# Altium schematic sheet AUDINT003_RevA_DUTHeader.SchDoc(AUDINT003_RevA_DUTHeader)
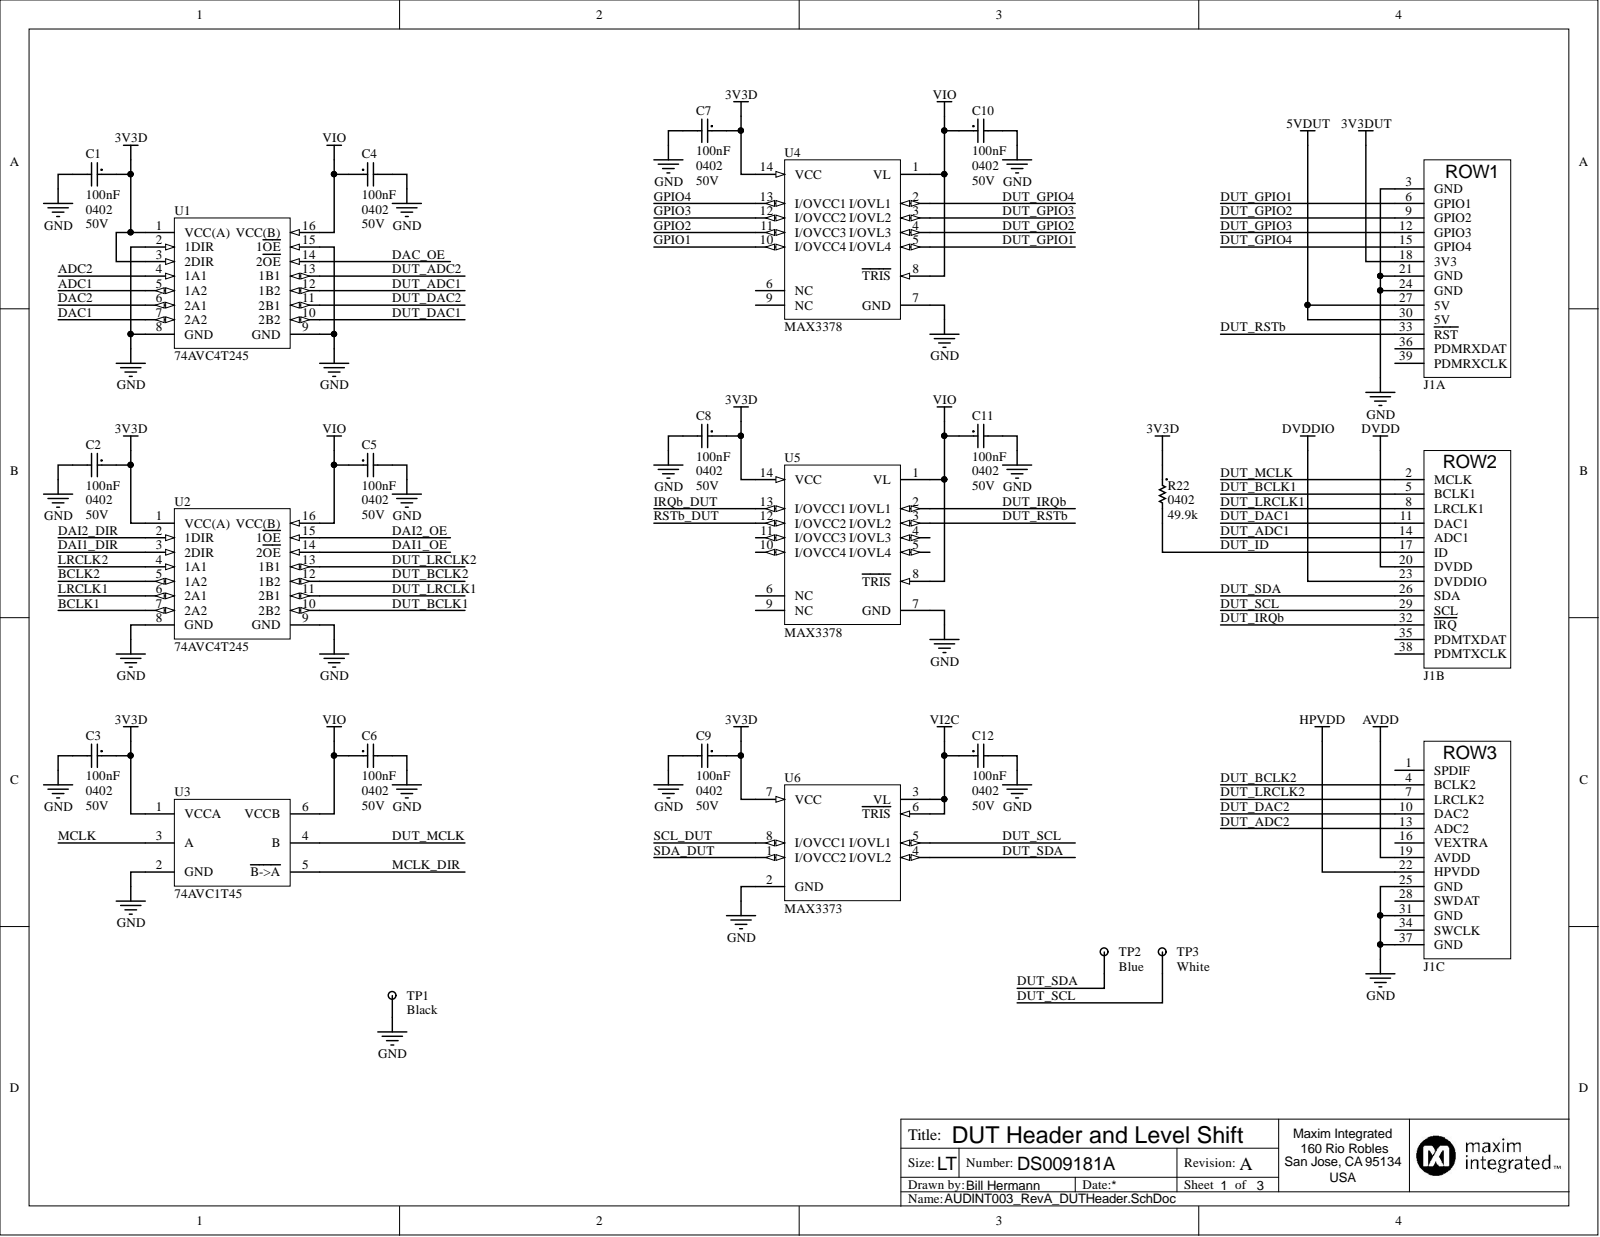
[diagram p0: AUDINT003_RevA_DUTHeader.SchDoc]

## Parts
C1  value 100nF  Package: 0402  [CAPC0402(1005)60_L]  (hidden comment: Capacitor)
C2  value 100nF  Package: 0402  [CAPC0402(1005)60_L]  (hidden comment: Capacitor)
C3  value 100nF  Package: 0402  [CAPC0402(1005)60_L]  (hidden comment: Capacitor)
C4  value 100nF  Package: 0402  [CAPC0402(1005)60_L]  (hidden comment: Capacitor)
C5  value 100nF  Package: 0402  [CAPC0402(1005)60_L]  (hidden comment: Capacitor)
C6  value 100nF  Package: 0402  [CAPC0402(1005)60_L]  (hidden comment: Capacitor)
C7  value 100nF  Package: 0402  [CAPC0402(1005)60_L]  (hidden comment: Capacitor)
C8  value 100nF  Package: 0402  [CAPC0402(1005)60_L]  (hidden comment: Capacitor)
C9  value 100nF  Package: 0402  [CAPC0402(1005)60_L]  (hidden comment: Capacitor)
C10  value 100nF  Package: 0402  [CAPC0402(1005)60_L]  (hidden comment: Capacitor)
C11  value 100nF  Package: 0402  [CAPC0402(1005)60_L]  (hidden comment: Capacitor)
C12  value 100nF  Package: 0402  [CAPC0402(1005)60_L]  (hidden comment: Capacitor)
J1  SOCKET_EVK3_1  [SOCKET_13x3_100mil_RA]  (value not drawn)  (parts A,B,C)
R22  value 49.9k  Package: 0402  [RESC0402(1005)_L]  (hidden comment: Res / 49.9kΩ / 1% / 0402)
TP1  Color: Black  [5011K-ND_TP_BLACK]  (hidden comment: TP_BLACK_5011)
TP2  Color: Blue  [5117_TP_MINI_BLUE]  (hidden comment: TP_MINI_BLUE_5117)
TP3  Color: White  [5002_TP_MINI_WHITE]  (hidden comment: TP_MINI_WHITE_5002)
U1  74AVC4T245  [PW16_N]
U2  74AVC4T245  [PW16_N]
U3  74AVC1T45  [MAA06A_L]
U4  MAX3378  [U14+1_N]
U5  MAX3378  [U14+1_N]
U6  MAX3373  [U8+1_N]

## Nets
3V3D: C1.1 C2.1 C3.1 C7.1 C8.1 C9.1 R22.1 U1.1(VCC(A)) U1.3(2DIR) U2.1(VCC(A)) U3.1(VCCA) U4.14(VCC) U5.14(VCC) U6.7(VCC)
3V3DUT: J1.18(3V3)
5VDUT: J1.27(5V) J1.30(5V)
AVDD: J1.19(AVDD)
DVDD: J1.20(DVDD)
DVDDIO: J1.23(DVDDIO)
GND: C1.2 C2.2 C3.2 C4.2 C5.2 C6.2 C7.2 C8.2 C9.2 C10.2 C11.2 C12.2 J1.3(GND) J1.21(GND) J1.24(GND) J1.25(GND) J1.31(GND) J1.37(GND) TP1.1 U1.2(1DIR) U1.8(GND) U1.9(GND) U1.15(1OE) U2.8(GND) U2.9(GND) U3.2(GND) U4.7(GND) U5.7(GND) U6.2(GND)
HPVDD: J1.22(HPVDD)
VI2C: C12.1 U6.3(VL) U6.6(TRIS)
VIO: C4.1 C5.1 C6.1 C10.1 C11.1 U1.16(VCC(B)) U2.16(VCC(B)) U3.6(VCCB) U4.1(VL) U4.8(TRIS) U5.1(VL) U5.8(TRIS)
ADC1: U1.5(1A2)
ADC2: U1.4(1A1)
BCLK1: U2.7(2A2)
BCLK2: U2.5(1A2)
DAC1: U1.7(2A2)
DAC2: U1.6(2A1)
DAC_OE: U1.14(2OE)
DAI1_DIR: U2.3(2DIR)
DAI1_OE: U2.14(2OE)
DAI2_DIR: U2.2(1DIR)
DAI2_OE: U2.15(1OE)
DUT_ADC1: J1.14(ADC1) U1.12(1B2)
DUT_ADC2: J1.13(ADC2) U1.13(1B1)
DUT_BCLK1: J1.5(BCLK1) U2.10(2B2)
DUT_BCLK2: J1.4(BCLK2) U2.12(1B2)
DUT_DAC1: J1.11(DAC1) U1.10(2B2)
DUT_DAC2: J1.10(DAC2) U1.11(2B1)
DUT_GPIO1: J1.6(GPIO1) U4.5(I/OVL4)
DUT_GPIO2: J1.9(GPIO2) U4.4(I/OVL3)
DUT_GPIO3: J1.12(GPIO3) U4.3(I/OVL2)
DUT_GPIO4: J1.15(GPIO4) U4.2(I/OVL1)
DUT_ID: J1.17(ID) R22.2
DUT_IRQb: J1.32(IRQ) U5.2(I/OVL1)
DUT_LRCLK1: J1.8(LRCLK1) U2.11(2B1)
DUT_LRCLK2: J1.7(LRCLK2) U2.13(1B1)
DUT_MCLK: J1.2(MCLK) U3.4(B)
DUT_RSTb: J1.33(RST) U5.3(I/OVL2)
DUT_SCL: J1.29(SCL) TP3.1 U6.5(I/OVL1)
DUT_SDA: J1.26(SDA) TP2.1 U6.4(I/OVL2)
GPIO1: U4.10(I/OVCC4)
GPIO2: U4.11(I/OVCC3)
GPIO3: U4.12(I/OVCC2)
GPIO4: U4.13(I/OVCC1)
IRQb_DUT: U5.13(I/OVCC1)
LRCLK1: U2.6(2A1)
LRCLK2: U2.4(1A1)
MCLK: U3.3(A)
MCLK_DIR: U3.5(B->A)
RSTb_DUT: U5.12(I/OVCC2)
SCL_DUT: U6.8(I/OVCC1)
SDA_DUT: U6.1(I/OVCC2)

Not connected: J1 1(SPDIF) 16(VEXTRA) 28(SWDAT) 34(SWCLK) 35(PDMTXDAT) 36(PDMRXDAT) 38(PDMTXCLK) 39(PDMRXCLK); U4 6(NC) 9(NC); U5 4(I/OVL3) 5(I/OVL4) 6(NC) 9(NC) 10(I/OVCC4) 11(I/OVCC3)

## Library data of the parts
C1-C12 = CAP_100nF_50V_0402: Capacitor / Ceramic / 100nF / 50V / X5R / 0402; Manufacturer PN: UMK105BJ104KV-F; Manufacturer: Taiyo Yuden; Tolerance: 10%; Voltage: 50V; Simulation: CAP; Mouser: 963-UMK105BJ104KV-F; Library Name: AUDINT002.SchLib; Dielectric: X5R; Component Kind: Standard
J1 = SOCKET_EVK3_1: Updated EVkit Daughter Card Socket; Manufacturer PN: SSW-113-02-G-T-RA; Manufacturer: Samtec; Rows: 3; Pitch: 0.1"; Pins: 39; Library Name: AUDINT002.SchLib; Component Kind: Standard
R22 = RES_49k9_0402: Resistor / Thick Film / 49.9kΩ / 1% / 0402; Manufacturer PN: RC0402FR-0749K9L; Manufacturer: Yageo; Power: 1/16W; Tolerance: 1%; Simulation: RESISTOR; Mouser: 603-RC0402FR-0749K9L; Library Name: AUDINT002.SchLib; DigiKey: 311-49.9KLRCT-ND; Component Kind: Standard
TP1 = TP_BLACK_5011: Manufacturer PN: 5011; Manufacturer: Keystone Electronics; Mouser: 534-5011; Library Name: AUDINT002.SchLib; DigiKey: 5011K-ND; Component Kind: Standard
TP2 = TP_MINI_BLUE_5117: Manufacturer PN: 5117; Manufacturer: Keystone Electronics; Mouser: 534-5117; Library Name: AUDINT002.SchLib; DigiKey: 5117K-ND; Component Kind: Standard
TP3 = TP_MINI_WHITE_5002: Manufacturer PN: 5002; Manufacturer: Keystone Electronics; Mouser: 534-5002; Library Name: AUDINT002.SchLib; DigiKey: 5002K-ND; Component Kind: Standard
U1 U2 = 74AVC4T245: Quad Level Translator; Manufacturer PN: 74AVC4T245PW-Q100J; Manufacturer: NXP; Package: TSSOP16; Mouser: 771-4AVC4T245PWQ100J; Library Name: AUDINT002.SchLib; Component Kind: Standard
U3 = 74AVC1T45: Single Level Translator; Manufacturer PN: 74AVC1T45GW; Manufacturer: NXP; Package: SOT363; Library Name: AUDINT002.SchLib; Component Kind: Standard
U4 U5 = MAX3378: Quad Level Translator; Manufacturer PN: MAX3378EEUD+; Manufacturer: Maxim; Package: TSSOP14; Library Name: AUDINT002.SchLib; Component Kind: Standard
U6 = MAX3373: Dual Level Translator; Manufacturer PN: MAX3373EEKA+; Manufacturer: Maxim; Package: SOT23-8; Library Name: AUDINT002.SchLib; Component Kind: Standard
